# Altium schematic sheet LED DRIVER.SchDoc
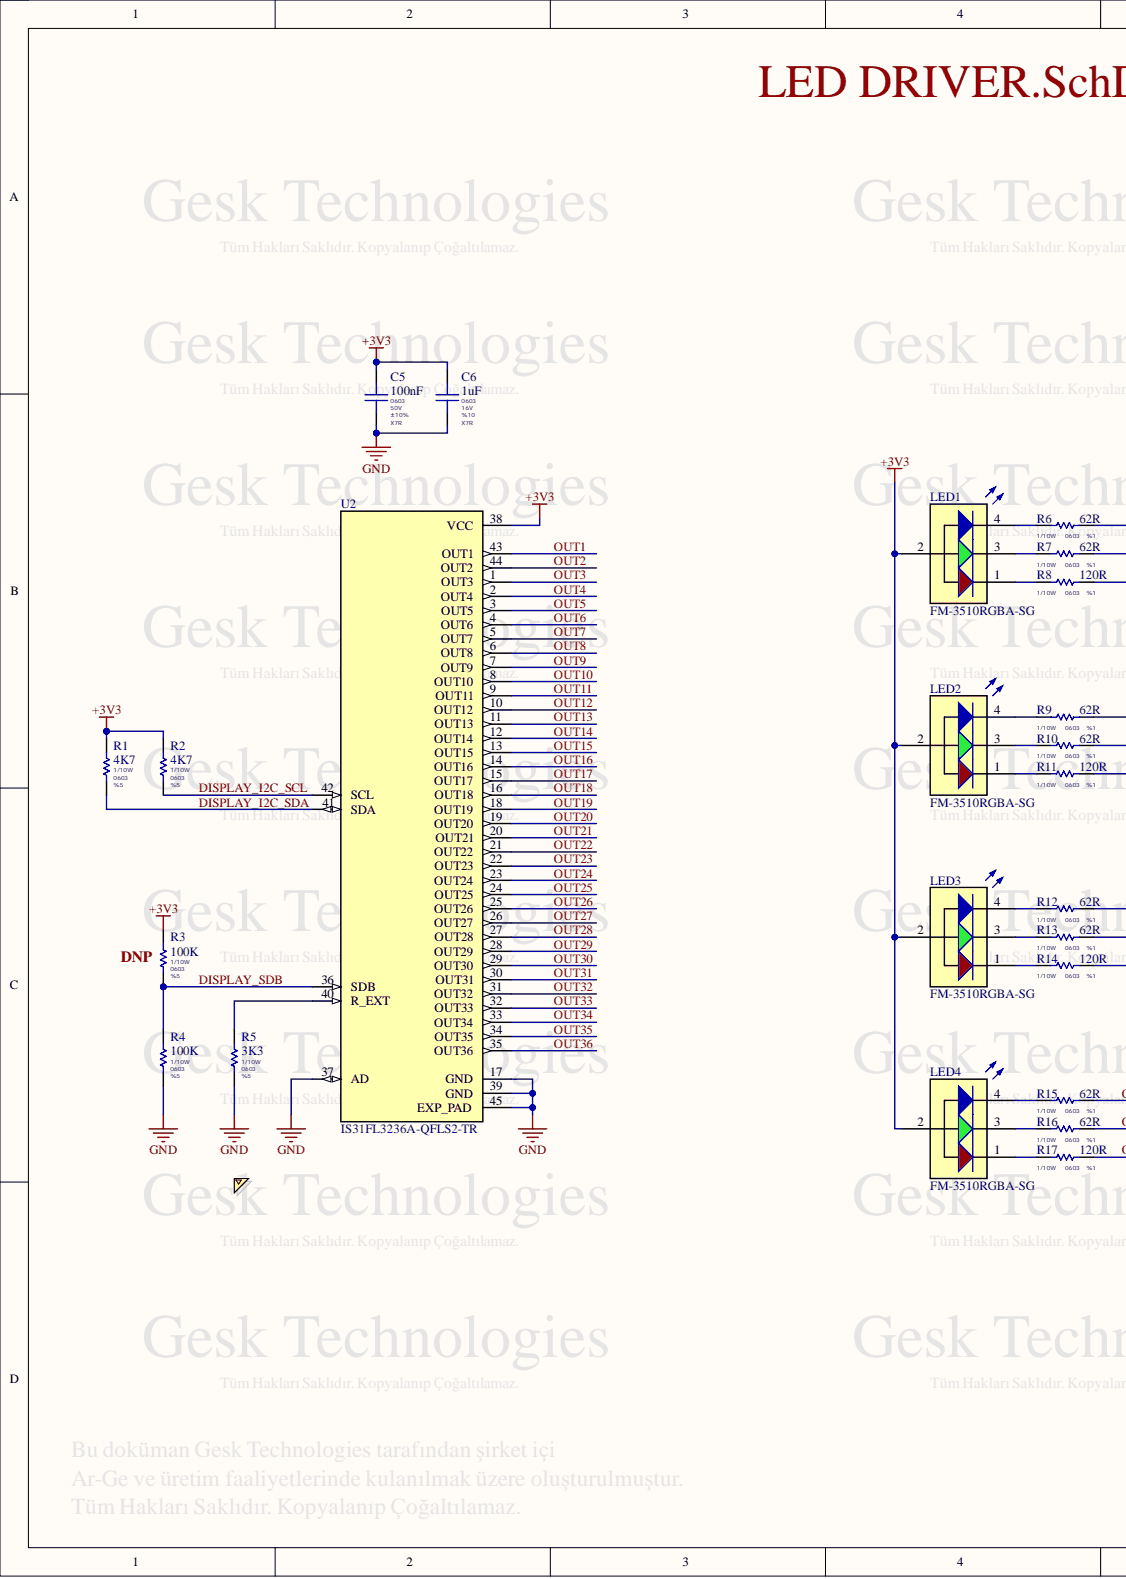
[diagram p0: LED DRIVER.SchDoc, left]
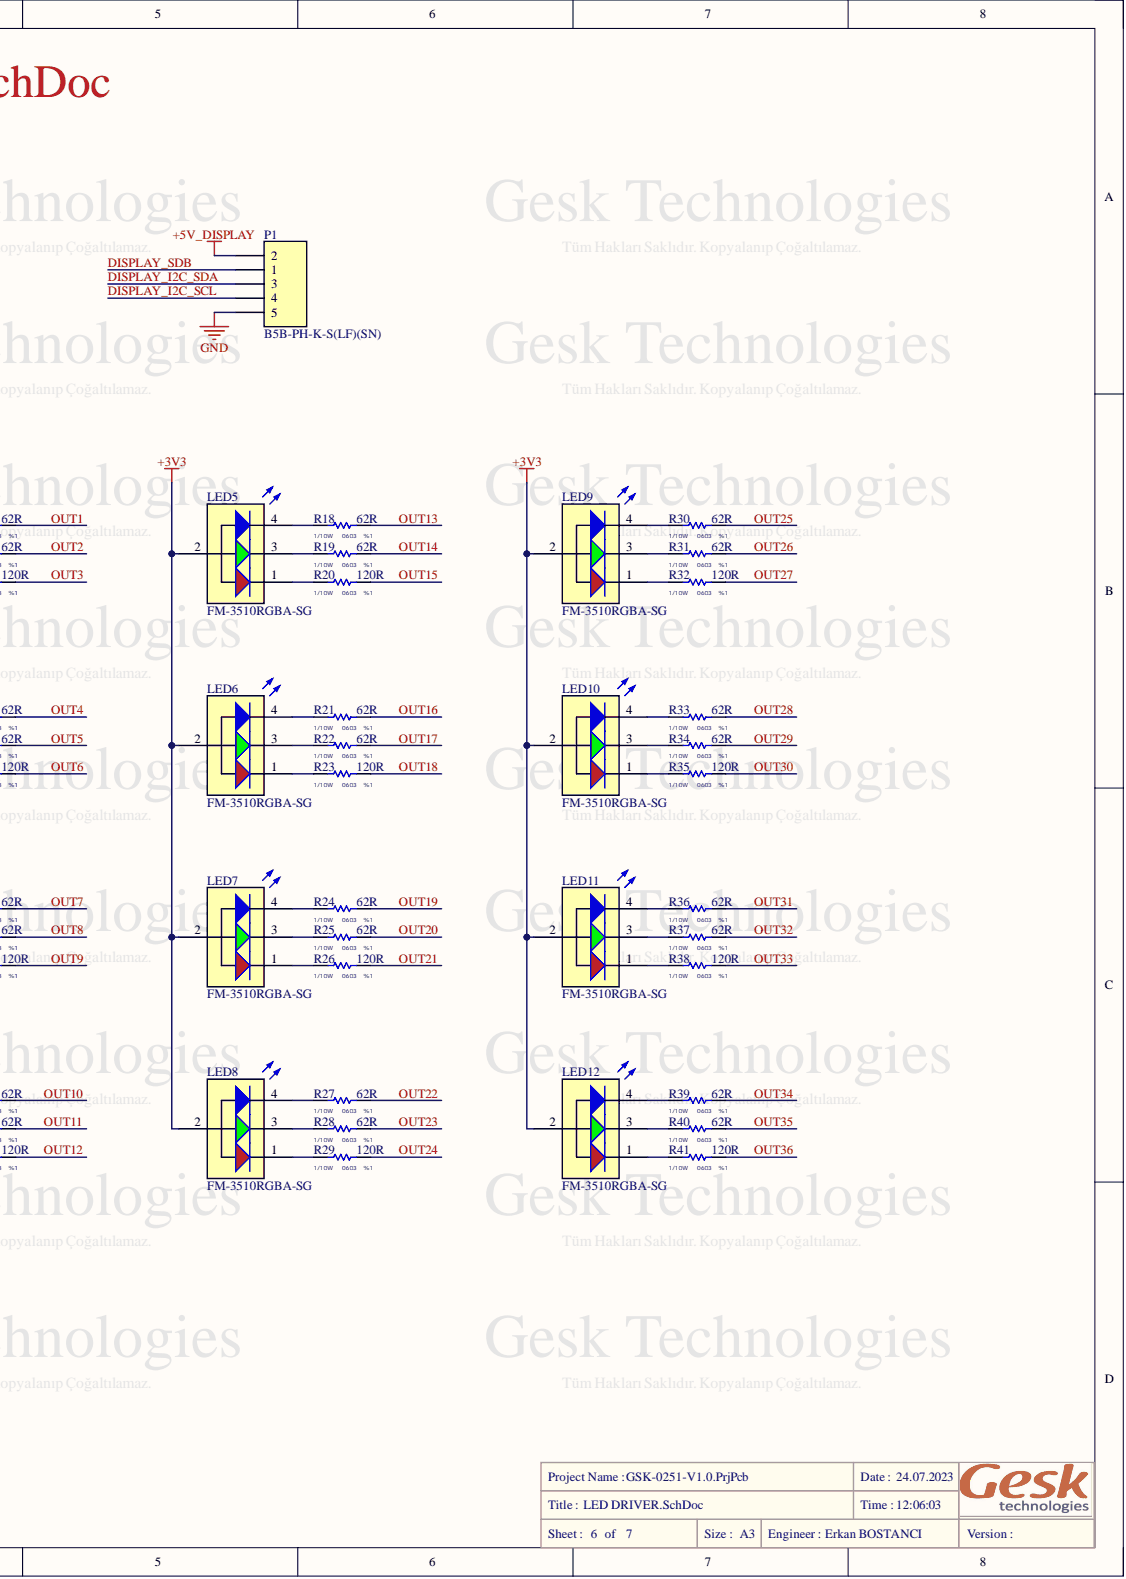
[diagram p1: LED DRIVER.SchDoc, right]

## Parts
C5  100nF  Package / Case: 0603  Temperature Coefficient: X7R  Tolerance: ±10%  Voltage: 50V  [SM/C0603N]
C6  1uF  Package / Case: 0603  Temperature Coefficient: X7R  Tolerance: %10  Voltage: 16V  [SM/C0603N]
LED1  FM-3510RGBA-SG  [RGB SMD,1.2x3.5mm]
LED2  FM-3510RGBA-SG  [RGB SMD,1.2x3.5mm]
LED3  FM-3510RGBA-SG  [RGB SMD,1.2x3.5mm]
LED4  FM-3510RGBA-SG  [RGB SMD,1.2x3.5mm]
LED5  FM-3510RGBA-SG  [RGB SMD,1.2x3.5mm]
LED6  FM-3510RGBA-SG  [RGB SMD,1.2x3.5mm]
LED7  FM-3510RGBA-SG  [RGB SMD,1.2x3.5mm]
LED8  FM-3510RGBA-SG  [RGB SMD,1.2x3.5mm]
LED9  FM-3510RGBA-SG  [RGB SMD,1.2x3.5mm]
LED10  FM-3510RGBA-SG  [RGB SMD,1.2x3.5mm]
LED11  FM-3510RGBA-SG  [RGB SMD,1.2x3.5mm]
LED12  FM-3510RGBA-SG  [RGB SMD,1.2x3.5mm]
P1  B5B-PH-K-S(LF)(SN)  [B5B-PH-K-S(LF)(SN)]
R1  4K7  Package: 0603  Power: 1/10W  Tolerance: %5  [SM/R0603N]
R2  4K7  Package: 0603  Power: 1/10W  Tolerance: %5  [SM/R0603N]
R3  100K  Package: 0603  Power: 1/10W  Tolerance: %5  [SM/R0603N]  (no BOM)
R4  100K  Package: 0603  Power: 1/10W  Tolerance: %5  [SM/R0603N]
R5  3K3  Package: 0603  Power: 1/10W  Tolerance: %5  [SM/R0603N]
R6  62R  Package: 0603  Tolerance: %1  [SM/R0603N]
R7  62R  Package: 0603  Power: 1/10W  Tolerance: %1  [SM/R0603N]
R8  120R  Package: 0603  Power: 1/10W  Tolerance: %1  [SM/R0603N]
R9  62R  Package: 0603  Tolerance: %1  [SM/R0603N]
R10  62R  Package: 0603  Power: 1/10W  Tolerance: %1  [SM/R0603N]
R11  120R  Package: 0603  Power: 1/10W  Tolerance: %1  [SM/R0603N]
R12  62R  Package: 0603  Tolerance: %1  [SM/R0603N]
R13  62R  Package: 0603  Power: 1/10W  Tolerance: %1  [SM/R0603N]
R14  120R  Package: 0603  Power: 1/10W  Tolerance: %1  [SM/R0603N]
R15  62R  Package: 0603  Power: 1/10W  Tolerance: %1  [SM/R0603N]
R16  62R  Package: 0603  Power: 1/10W  Tolerance: %1  [SM/R0603N]
R17  120R  Package: 0603  Power: 1/10W  Tolerance: %1  [SM/R0603N]
R18  62R  Package: 0603  Tolerance: %1  [SM/R0603N]
R19  62R  Package: 0603  Power: 1/10W  Tolerance: %1  [SM/R0603N]
R20  120R  Package: 0603  Power: 1/10W  Tolerance: %1  [SM/R0603N]
R21  62R  Package: 0603  Tolerance: %1  [SM/R0603N]
R22  62R  Package: 0603  Power: 1/10W  Tolerance: %1  [SM/R0603N]
R23  120R  Package: 0603  Power: 1/10W  Tolerance: %1  [SM/R0603N]
R24  62R  Package: 0603  Tolerance: %1  [SM/R0603N]
R25  62R  Package: 0603  Power: 1/10W  Tolerance: %1  [SM/R0603N]
R26  120R  Package: 0603  Power: 1/10W  Tolerance: %1  [SM/R0603N]
R27  62R  Package: 0603  Power: 1/10W  Tolerance: %1  [SM/R0603N]
R28  62R  Package: 0603  Power: 1/10W  Tolerance: %1  [SM/R0603N]
R29  120R  Package: 0603  Power: 1/10W  Tolerance: %1  [SM/R0603N]
R30  62R  Package: 0603  Tolerance: %1  [SM/R0603N]
R31  62R  Package: 0603  Power: 1/10W  Tolerance: %1  [SM/R0603N]
R32  120R  Package: 0603  Power: 1/10W  Tolerance: %1  [SM/R0603N]
R33  62R  Package: 0603  Tolerance: %1  [SM/R0603N]
R34  62R  Package: 0603  Power: 1/10W  Tolerance: %1  [SM/R0603N]
R35  120R  Package: 0603  Power: 1/10W  Tolerance: %1  [SM/R0603N]
R36  62R  Package: 0603  Tolerance: %1  [SM/R0603N]
R37  62R  Package: 0603  Power: 1/10W  Tolerance: %1  [SM/R0603N]
R38  120R  Package: 0603  Power: 1/10W  Tolerance: %1  [SM/R0603N]
R39  62R  Package: 0603  Power: 1/10W  Tolerance: %1  [SM/R0603N]
R40  62R  Package: 0603  Power: 1/10W  Tolerance: %1  [SM/R0603N]
R41  120R  Package: 0603  Power: 1/10W  Tolerance: %1  [SM/R0603N]
U2  IS31FL3236A-QFLS2-TR  [QFN-44 (5x5)]

## Nets
+3V3: C5.1 C6.2 LED1.2 LED2.2 LED3.2 LED4.2 LED5.2 LED6.2 LED7.2 LED8.2 LED9.2 LED10.2 LED11.2 LED12.2 R1.2 R2.2 R3.2 U2.38(VCC)
+5V_DISPLAY: P1.2
GND: C5.2 C6.1 P1.5 R4.1 R5.1 U2.17(GND) U2.37(AD) U2.39(GND) U2.45(EXP_PAD)
DISPLAY_I2C_SCL: P1.4 R2.1 U2.42(SCL)
DISPLAY_I2C_SDA: P1.3 R1.1 U2.41(SDA)
DISPLAY_SDB: P1.1 R3.1 R4.2 U2.36(SDB)
OUT1: R6.1 U2.43(OUT1)
OUT2: R7.1 U2.44(OUT2)
OUT3: R8.1 U2.1(OUT3)
OUT4: R9.1 U2.2(OUT4)
OUT5: R10.1 U2.3(OUT5)
OUT6: R11.1 U2.4(OUT6)
OUT7: R12.1 U2.5(OUT7)
OUT8: R13.1 U2.6(OUT8)
OUT9: R14.1 U2.7(OUT9)
OUT10: R15.1 U2.8(OUT10)
OUT11: R16.1 U2.9(OUT11)
OUT12: R17.1 U2.10(OUT12)
OUT13: R18.1 U2.11(OUT13)
OUT14: R19.1 U2.12(OUT14)
OUT15: R20.1 U2.13(OUT15)
OUT16: R21.1 U2.14(OUT16)
OUT17: R22.1 U2.15(OUT17)
OUT18: R23.1 U2.16(OUT18)
OUT19: R24.1 U2.18(OUT19)
OUT20: R25.1 U2.19(OUT20)
OUT21: R26.1 U2.20(OUT21)
OUT22: R27.1 U2.21(OUT22)
OUT23: R28.1 U2.22(OUT23)
OUT24: R29.1 U2.23(OUT24)
OUT25: R30.1 U2.24(OUT25)
OUT26: R31.1 U2.25(OUT26)
OUT27: R32.1 U2.26(OUT27)
OUT28: R33.1 U2.27(OUT28)
OUT29: R34.1 U2.28(OUT29)
OUT30: R35.1 U2.29(OUT30)
OUT31: R36.1 U2.30(OUT31)
OUT32: R37.1 U2.31(OUT32)
OUT33: R38.1 U2.32(OUT33)
OUT34: R39.1 U2.33(OUT34)
OUT35: R40.1 U2.34(OUT35)
OUT36: R41.1 U2.35(OUT36)
NetLED1_1: LED1.1 R8.2
NetLED1_3: LED1.3 R7.2
NetLED1_4: LED1.4 R6.2
NetLED2_1: LED2.1 R11.2
NetLED2_3: LED2.3 R10.2
NetLED2_4: LED2.4 R9.2
NetLED3_1: LED3.1 R14.2
NetLED3_3: LED3.3 R13.2
NetLED3_4: LED3.4 R12.2
NetLED4_1: LED4.1 R17.2
NetLED4_3: LED4.3 R16.2
NetLED4_4: LED4.4(Tüm) R15.2
NetLED5_1: LED5.1 R20.2
NetLED5_3: LED5.3 R19.2
NetLED5_4: LED5.4 R18.2
NetLED6_1: LED6.1 R23.2
NetLED6_3: LED6.3 R22.2
NetLED6_4: LED6.4 R21.2
NetLED7_1: LED7.1 R26.2
NetLED7_3: LED7.3 R25.2
NetLED7_4: LED7.4 R24.2
NetLED8_1: LED8.1 R29.2
NetLED8_3: LED8.3 R28.2
NetLED8_4: LED8.4 R27.2
NetLED9_1: LED9.1 R32.2
NetLED9_3: LED9.3 R31.2
NetLED9_4: LED9.4 R30.2
NetLED10_1: LED10.1 R35.2
NetLED10_3: LED10.3 R34.2
NetLED10_4: LED10.4 R33.2
NetLED11_1: LED11.1 R38.2
NetLED11_3: LED11.3 R37.2
NetLED11_4: LED11.4 R36.2
NetLED12_1: LED12.1 R41.2
NetLED12_3: LED12.3 R40.2
NetLED12_4: LED12.4(Tüm) R39.2
NetR5_2: R5.2 U2.40(R_EXT)

## Library data of the parts
C5 = CapNP: CAP CER 0.1UF 50V X7R 0603; Manufacturer Part Number: smd cap; Component Kind: Standard; Signal Integrity: Cap; Simulation: CAP; Value: 100nF; Library Name: Passives_GESK.IntLib
C6 = CapNP: CAP CER 1UF 16V X7R 0603; Manufacturer Part Number: smd cap; Component Kind: Standard; Signal Integrity: Cap; Simulation: CAP; Value: 1uF; Library Name: Passives_GESK.IntLib
LED1-LED12 = LED RGB SMD: Side stickers -30℃~+85℃ Common anode RGB 110° SMD,1.3x3.5mm Light Emitting Diodes (LED) ROHS; Manufacturer: Foshan NationStar Optoelectronics; Component Kind: Standard; Library Name: Schlib1.SchLib; Link: https://www.lcsc.com/product-detail/Light-Emitting-Diodes-LED_Foshan-NationStar-Optoelectronics-FM-3510RGBA-SG_C727903.html; Manufacturer Part Number: FM-3510RGBA-SG; Mounting Type: SMD; Package / Case: SMD,1.3x3.5mm
P1 = B5B-PH-K: Connector Header Through Hole 5 position 0.079" (2.00mm); Manufacturer: JST Sales America Inc.; Current Max: 3A; Category: Connectors, Interconnects; Component Kind: Standard; ComponentLink1Description: http://media.digikey.com/pdf/Data%20Sheets/Phoenix%20Contact%20PDFs/1757268.pdf; ComponentLink1URL: http://www.jst-mfg.com/product/pdf/eng/eXH.pdf; Manufacturer Part Number: B5B-PH-K-S(LF)(SN); Pitch: 2.00mm; Library Name: Connectors_GESK.IntLib
R1 R2 = R: RES SMD 4.7K OHM 5% 1/10W 0603; Manufacturer Part Number: Smd Res; Component Kind: Standard; Signal Integrity: Resistor; Value: 4K7; Library Name: Passives_GESK.IntLib
R3 R4 = R: RES SMD 100K OHM 5% 1/10W 0603; Manufacturer Part Number: Smd Res; Signal Integrity: Resistor; Value: 100K; Library Name: Passives_GESK.IntLib
  R4: Component Kind: Standard
R5 = R: RES SMD 3K3 OHM 5% 1/10W 0603; Manufacturer Part Number: Smd Res; Component Kind: Standard; Signal Integrity: Resistor; Value: 3K3; Library Name: Passives_GESK.IntLib
R6 R7 R9 R10 R12 R13 R15 R16 R18 R19 R21 R22 R24 R25 R27 R28 R30 R31 R33 R34 R36 R37 R39 R40 = R: RES SMD 62R OHM 1% 1/10W 0603; Manufacturer Part Number: Smd Res; Component Kind: Standard; Signal Integrity: Resistor; Value: 62R; Library Name: Passives_GESK.IntLib
  R6 R9 R12 R18 R21 R24 R30 R33 R36: Power: 1/10W
R8 R11 R14 R17 R20 R23 R26 R29 R32 R35 R38 R41 = R: RES SMD 120R OHM 1% 1/10W 0603; Manufacturer Part Number: Smd Res; Component Kind: Standard; Signal Integrity: Resistor; Value: 120R; Library Name: Passives_GESK.IntLib
U2 = IS31FL3236A-QFLS2-TR: LED Driver IC 36 Output Linear Constant Current Dimming 38mA 44-QFN (5x5); Manufacturer: Lumissil Microsystems; Component Kind: Standard; Library Name: Schlib1.SchLib; Link: https://www.digikey.com/en/products/detail/lumissil-microsystems/IS31FL3236A-QFLS2-TR/7219610?s=N4IgTCBcDaIJIGUDMBGAYgGSWJA2AggLQCKmCYhAKgEogC6AvkA; Manufacturer Part Number: IS31FL3236A-QFLS2-TR; Mounting Type: SMD; Package / Case: 44-QFN (5x5)
